# Altium schematic sheet Interface.SchDoc(Interface)
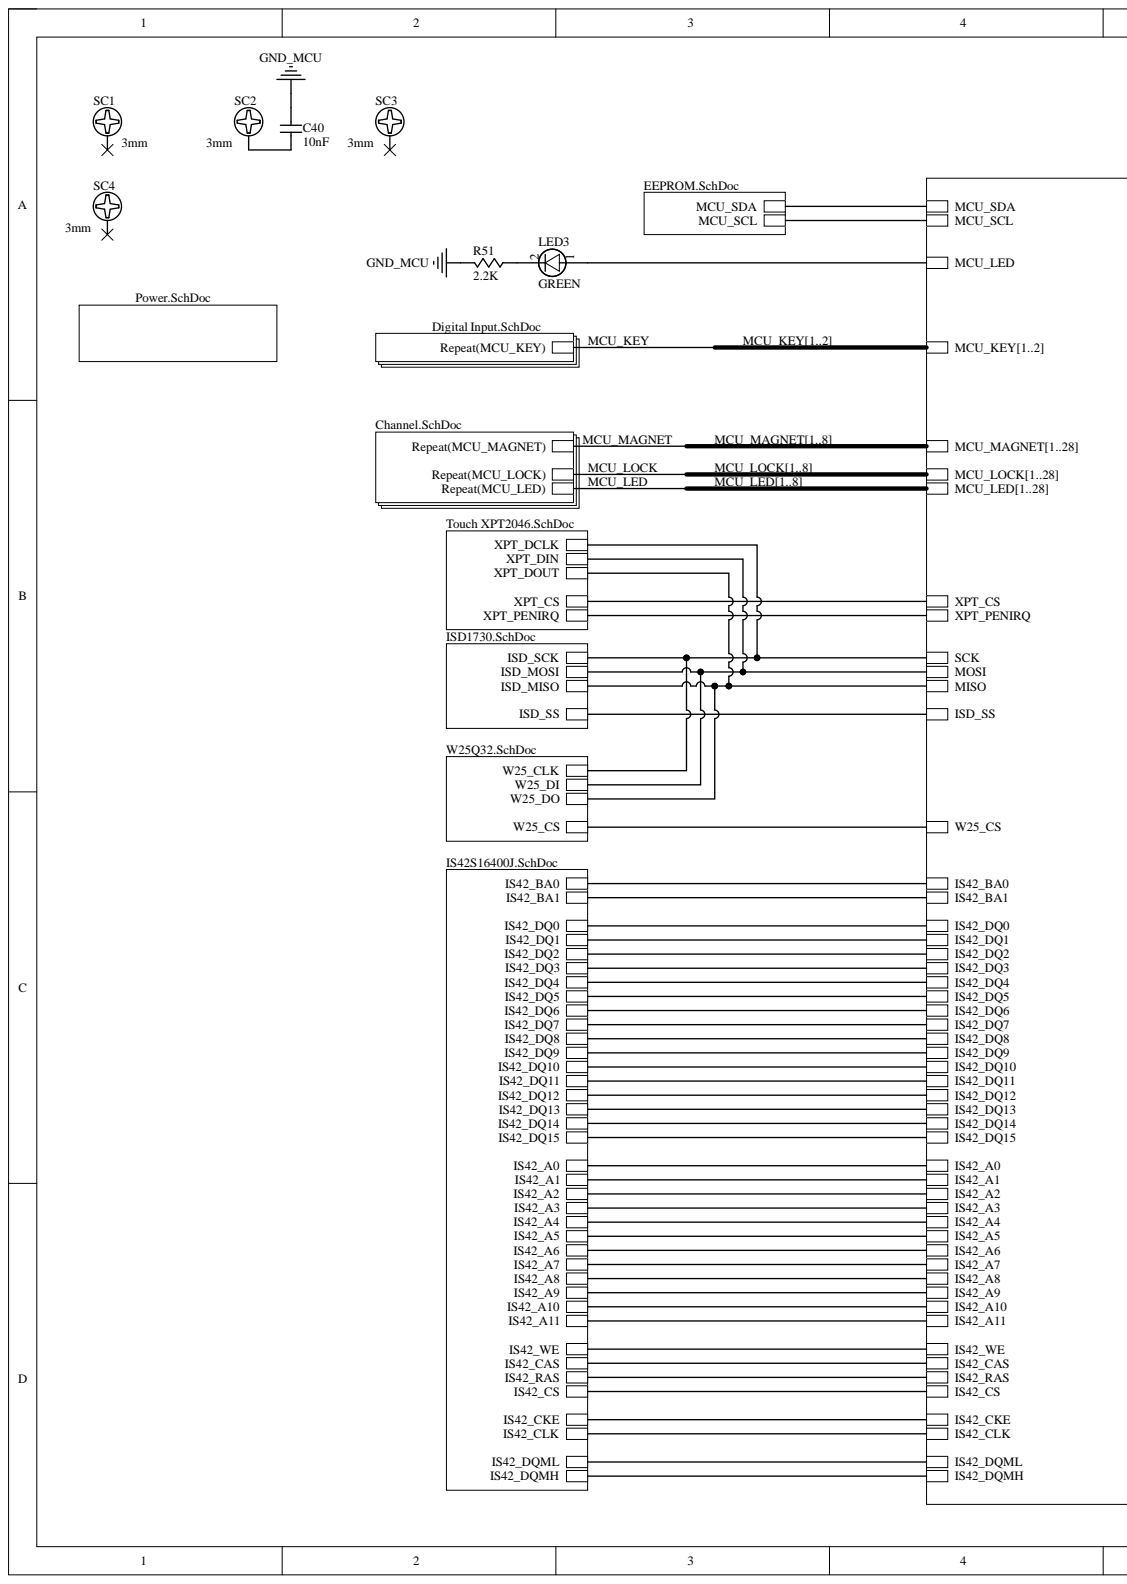
[diagram p0: Interface.SchDoc, left]
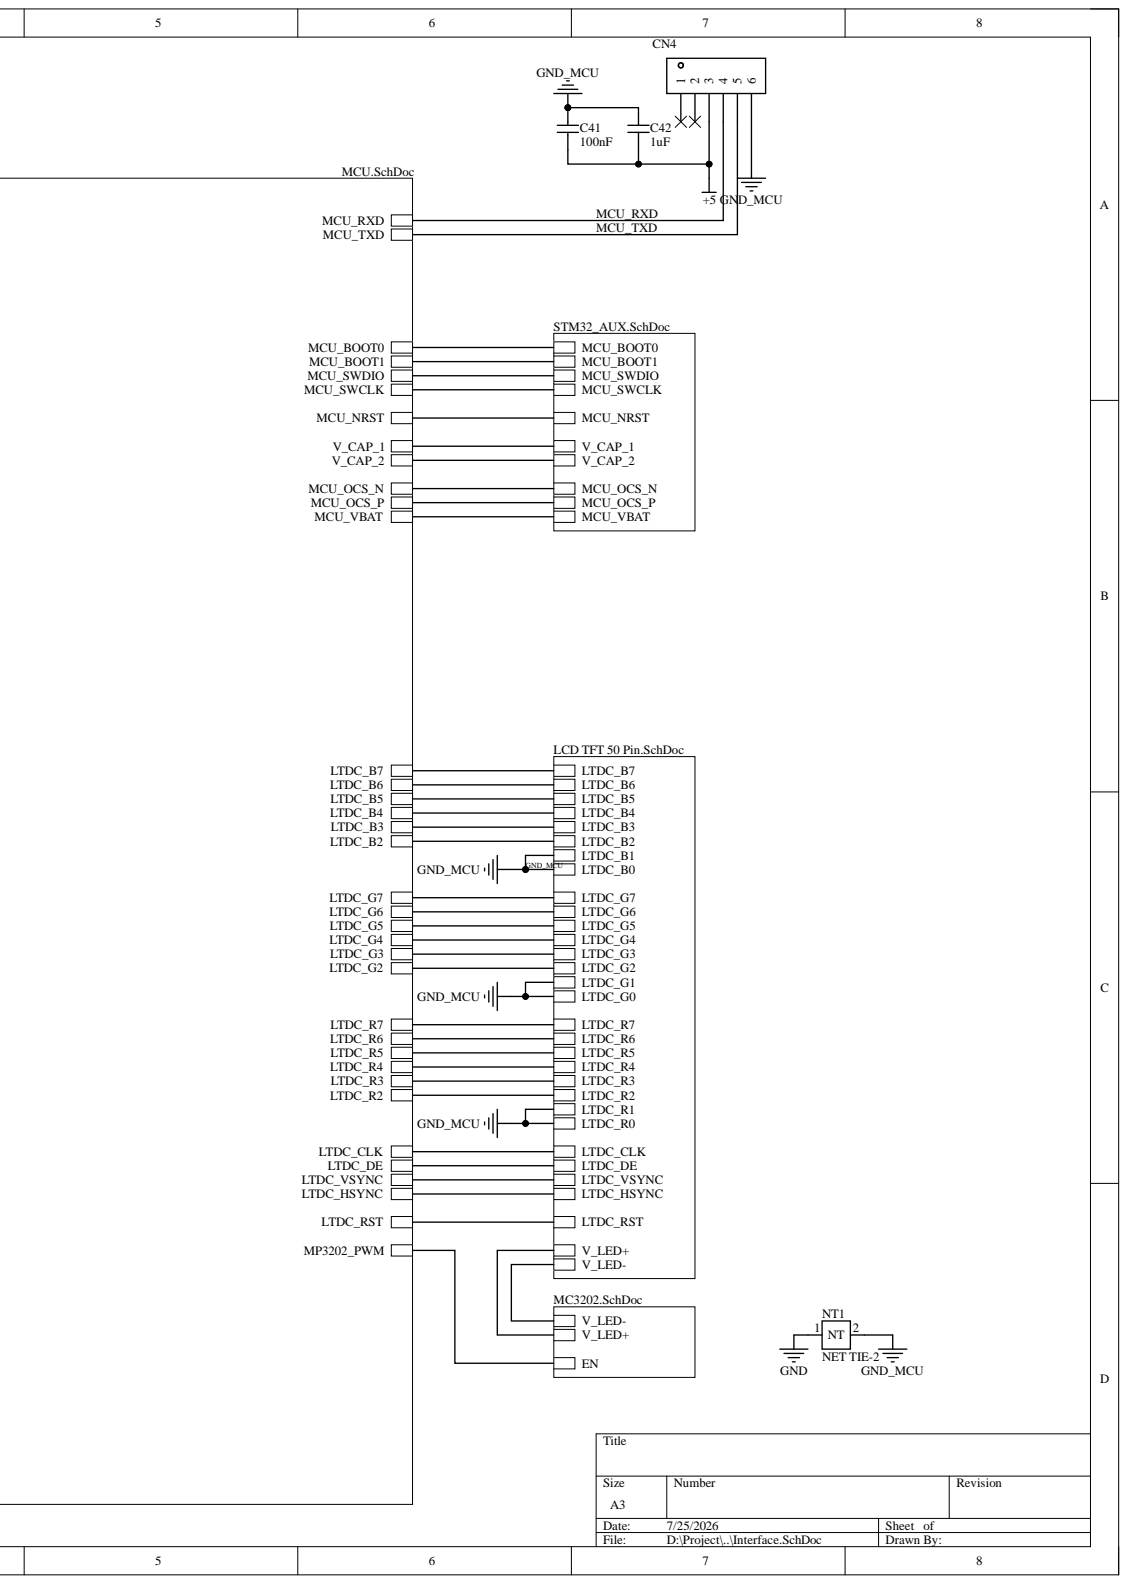
[diagram p1: Interface.SchDoc, right]

## Parts
C40  value 10nF  [CAP-CER-7x2.5-Ls5]  (hidden comment: CAP Cer 10nF-1KV-X7R-THD)
C41  value 100nF  [C-0805]  (hidden comment: CAP Cer 100nF-50V-X7R-SMD)
C42  value 1uF  [C-0805]  (hidden comment: CAP Cer 1uF-50V-X7R-SMD)
CN4  Connector XH-Straight-THD-2.54mm  [XH-6]  (value not drawn)
LED3  Color: GREEN  [LED-1206-Y]  (hidden comment: LED GREEN-1206-SMD)
NT1  NET TIE-2  [NET TIE-2]
R51  value 2.2K  [R-0805]  (hidden comment: RES 2.2K-5%-1/8W-SMD)
SC1  Size: 3mm  [Screw_M3]  (hidden comment: Screw 3mm)
SC2  Size: 3mm  [Screw_M3]  (hidden comment: Screw 3mm)
SC3  Size: 3mm  [Screw_M3]  (hidden comment: Screw 3mm)
SC4  Size: 3mm  [Screw_M3]  (hidden comment: Screw 3mm)

## Nets
+5: C41.1 C42.1 CN4.3
GND: NT1.1
GND_MCU: C40.2 C41.2 C42.2 CN4.6 NT1.2 R51.2
MCU_RXD: CN4.4
MCU_TXD: CN4.5
NetC40_1: C40.1 SC2.1
NetCN4_1: CN4.1
NetCN4_2: CN4.2
NetLED3_1: LED3.1
NetLED3_2: LED3.2 R51.1
NetSC1_1: SC1.1
NetSC3_1: SC3.1
NetSC4_1: SC4.1
EN:
IS42_A0:
IS42_A1:
IS42_A2:
IS42_A3:
IS42_A4:
IS42_A5:
IS42_A6:
IS42_A7:
IS42_A8:
IS42_A9:
IS42_A10:
IS42_A11:
IS42_BA0:
IS42_BA1:
IS42_CAS:
IS42_CKE:
IS42_CLK:
IS42_CS:
IS42_DQ0:
IS42_DQ1:
IS42_DQ2:
IS42_DQ3:
IS42_DQ4:
IS42_DQ5:
IS42_DQ6:
IS42_DQ7:
IS42_DQ8:
IS42_DQ9:
IS42_DQ10:
IS42_DQ11:
IS42_DQ12:
IS42_DQ13:
IS42_DQ14:
IS42_DQ15:
IS42_DQMH:
IS42_DQML:
IS42_RAS:
IS42_WE:
ISD_MISO:
ISD_MOSI:
ISD_SCK:
ISD_SS:
LTDC_B2:
LTDC_B3:
LTDC_B4:
LTDC_B5:
LTDC_B6:
LTDC_B7:
LTDC_CLK:
LTDC_DE:
LTDC_G2:
LTDC_G3:
LTDC_G4:
LTDC_G5:
LTDC_G6:
LTDC_G7:
LTDC_HSYNC:
LTDC_R2:
LTDC_R3:
LTDC_R4:
LTDC_R5:
LTDC_R6:
LTDC_R7:
LTDC_RST:
LTDC_VSYNC:
MCU_BOOT0:
MCU_BOOT1:
MCU_KEY1:
MCU_KEY2:
MCU_LED1:
MCU_LED2:
MCU_LED3:
MCU_LED4:
MCU_LED5:
MCU_LED6:
MCU_LED7:
MCU_LED8:
MCU_LOCK1:
MCU_LOCK2:
MCU_LOCK3:
MCU_LOCK4:
MCU_LOCK5:
MCU_LOCK6:
MCU_LOCK7:
MCU_LOCK8:
MCU_MAGNET1:
MCU_MAGNET2:
MCU_MAGNET3:
MCU_MAGNET4:
MCU_MAGNET5:
MCU_MAGNET6:
MCU_MAGNET7:
MCU_MAGNET8:
MCU_NRST:
MCU_OCS_N:
MCU_OCS_P:
MCU_SCL:
MCU_SDA:
MCU_SWCLK:
MCU_SWDIO:
MCU_VBAT:
V_CAP_1:
V_CAP_2:
V_LED+:
V_LED-:
W25_CS:
XPT_CS:
XPT_PENIRQ:

## Library data of the parts
C40 = CAP-CER: CAPACITOR; Phone Number: 0915 8700 314; Tolerance: X7R; Type: THD; Voltage: 1KV; Component Kind: Standard; ComponentLink1Description: Linkedin; ComponentLink1URL: https://ir.linkedin.com/in/mahdi-bagherzade; Published Date: 9-15-2024; Signal Integrity: CAP; Library Name: Mahdi-Bagherzadeh.IntLib; Publisher: Mahdi Bagherzadeh
C41 C42 = CAP-CER: CAPACITOR; Phone Number: 0915 8700 314; Tolerance: X7R; Type: SMD; Voltage: 50V; Component Kind: Standard; ComponentLink1Description: Linkedin; ComponentLink1URL: https://ir.linkedin.com/in/mahdi-bagherzade; Published Date: 9-15-2024; Signal Integrity: CAP; Library Name: Mahdi-Bagherzadeh.IntLib; Publisher: Mahdi Bagherzadeh
CN4 = CN-6pin: CONNECTOR; Phone Number: 0915 8700 314; Value: XH; Component Kind: Standard; ComponentLink1Description: Linkedin; ComponentLink1URL: https://ir.linkedin.com/in/mahdi-bagherzade; Published Date: 9-15-2024; Signal Integrity: CN; Size: 2.54mm; Type: Straight-THD; Library Name: Mahdi-Bagherzadeh.IntLib; Publisher: Mahdi Bagherzadeh
LED3 = LED: Phone Number: 0915 8700 314; Type: SMD; Component Kind: Standard; ComponentLink1Description: Linkedin; ComponentLink1URL: https://ir.linkedin.com/in/mahdi-bagherzade; Description: LED; Published Date: 9-15-2024; Size: 1206; Library Name: Mahdi-Bagherzadeh.IntLib; Publisher: Mahdi Bagherzadeh
NT1 = NET TIE-2: Phone Number: 0915 8700 314; Component Kind: Net Tie; ComponentLink1Description: Linkedin; ComponentLink1URL: https://ir.linkedin.com/in/mahdi-bagherzade; Published Date: 9-15-2024; Library Name: Mahdi-Bagherzadeh.IntLib; Publisher: Mahdi Bagherzadeh
R51 = RES: RESISTOR; Phone Number: 0915 8700 314; Power: 1/8W; Tolerance: 5%; Component Kind: Standard; ComponentLink1Description: Linkedin; ComponentLink1URL: https://ir.linkedin.com/in/mahdi-bagherzade; Published Date: 9-15-2024; Signal Integrity: RES; Type: SMD; Library Name: Mahdi-Bagherzadeh.IntLib; Publisher: Mahdi Bagherzadeh
SC1-SC4 = SCREW: Phone Number: 0915 8700 314; Component Kind: Standard; ComponentLink1Description: Linkedin; ComponentLink1URL: https://ir.linkedin.com/in/mahdi-bagherzade; Description: SCREW; Published Date: 9-15-2024; Library Name: Mahdi-Bagherzadeh.IntLib; Publisher: Mahdi Bagherzadeh
